# Altium schematic sheet 2414_ScreenHolder.SchDoc
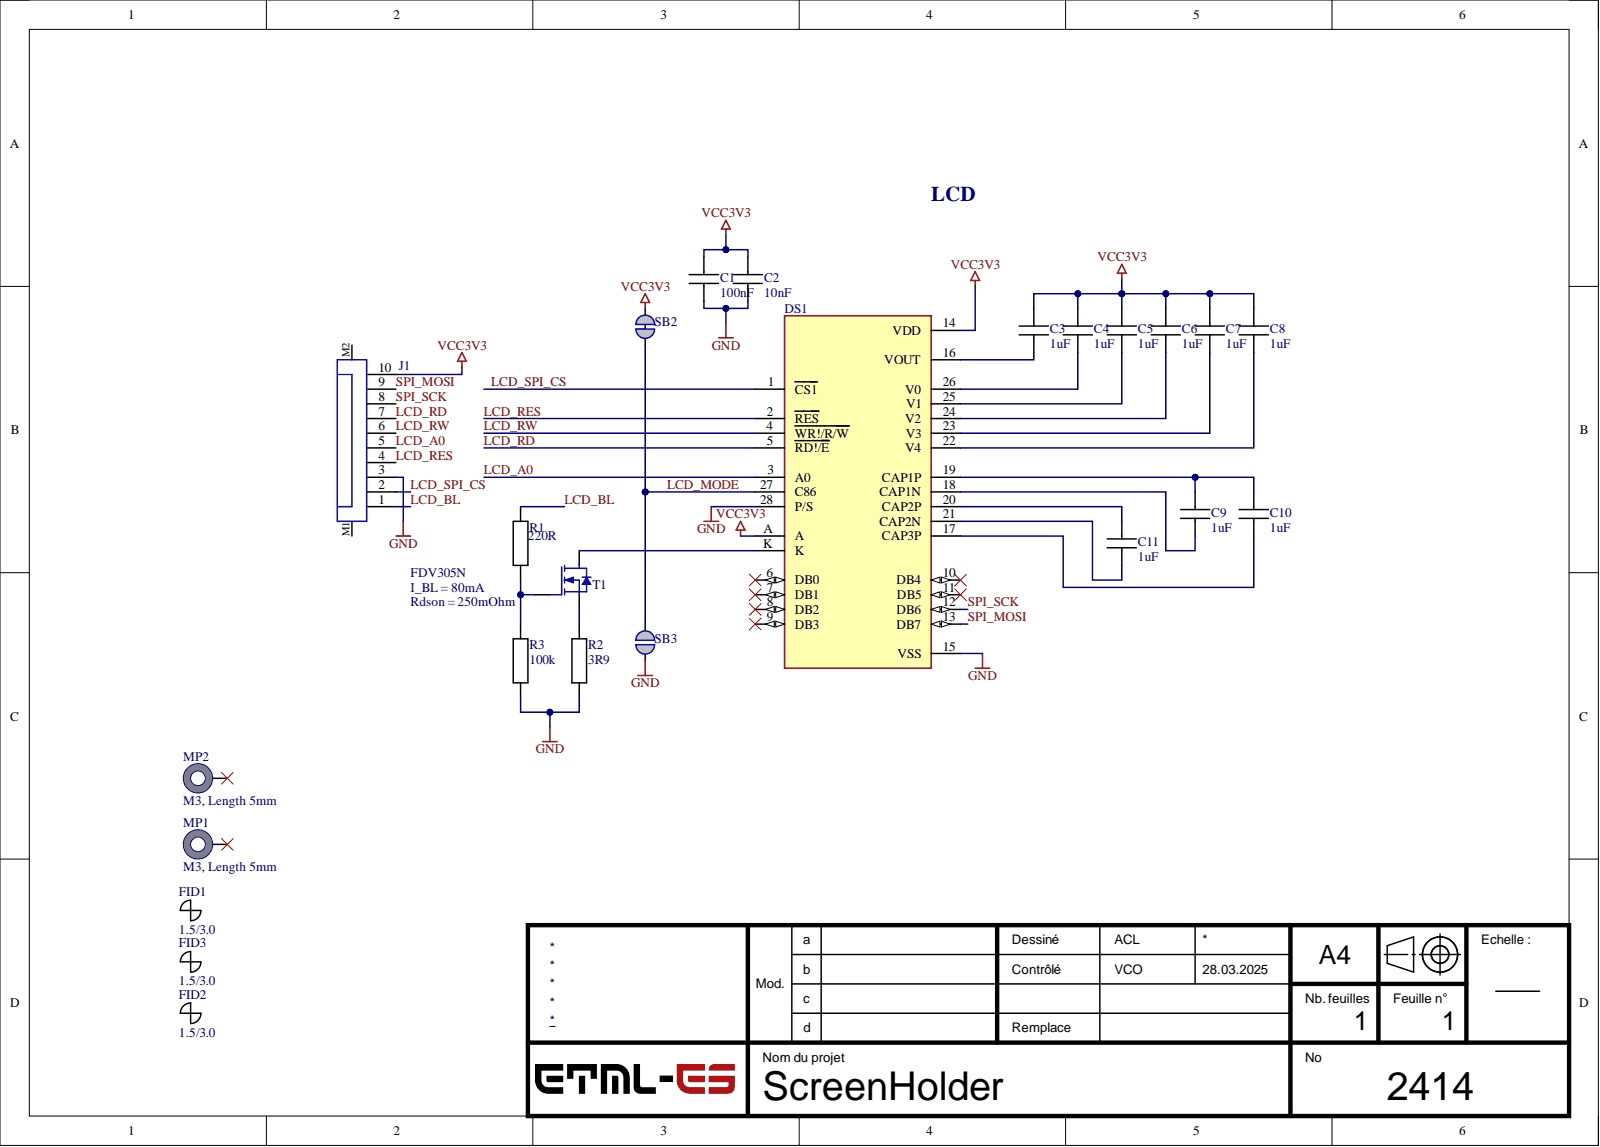
[diagram p0: 2414_ScreenHolder.SchDoc]

## Parts
C1  100nF  [CAPC1608X08M]
C2  10nF  [CAPC1608X08M]
C3  1uF  [CAPC1608X08M]
C4  1uF  [CAPC1608X08M]
C5  1uF  [CAPC1608X08M]
C6  1uF  [CAPC1608X08M]
C7  1uF  [CAPC1608X08M]
C8  1uF  [CAPC1608X08M]
C9  1uF  [CAPC1608X08M]
C10  1uF  [CAPC1608X08M]
C11  1uF  [CAPC1608X08M]
DS1  NHD-C12864LZ-FSW-FBW-3V3  [LCD_NHD-C12864LZ-FSW-FBW-3V3]  (value not drawn)
FID1  1.5/3.0  [FID-150-300]  (no BOM)
FID2  1.5/3.0  [FID-150-300]  (no BOM)
FID3  1.5/3.0  [FID-150-300]  (no BOM)
J1  68611014422  [68611014422]  (value not drawn)
MP1  M3, Length 5mm  Length: 5mm  [9774050360]
MP2  M3, Length 5mm  Length: 5mm  [9774050360]
R1  220R  [RESC1608X06M]
R2  3R9  [RESC1608X06M]
R3  100k  [RESC1608X06M]
SB2  Solderbridge  [Solderbridge_Shorted]  (value not drawn)
SB3  Solderbridge  [Solderbridge_4]  (value not drawn)  (no BOM)
T1  FDV305N  [SOT95P240X111-3N]

## Nets
GND: C1.1 C2.1 DS1.15(VSS) DS1.28(P/S) J1.3 R2.1 R3.1 SB3.2
VCC3V3: C1.2 C2.2 C3.2 C4.2 C5.2 C6.2 C7.2 C8.2 DS1.14(VDD) DS1.A J1.10 SB2.1
LCD_A0: DS1.3(A0) J1.5
LCD_BL: J1.1 R1.2
LCD_MODE: DS1.27(C86) SB2.2 SB3.1
LCD_RD: DS1.5(RD!/E) J1.7
LCD_RES: DS1.2(RES) J1.4
LCD_RW: DS1.4(WR!/R/W) J1.6
LCD_SPI_CS: DS1.1(CS1) J1.2
SPI_MOSI: DS1.13(DB7) J1.9
SPI_SCK: DS1.12(DB6) J1.8
NetC3_1: C3.1 DS1.16(VOUT)
NetC4_1: C4.1 DS1.26(V0)
NetC5_1: C5.1 DS1.25(V1)
NetC6_1: C6.1 DS1.24(V2)
NetC7_1: C7.1 DS1.23(V3)
NetC8_1: C8.1 DS1.22(V4)
NetC9_1: C9.1 DS1.18(CAP1N)
NetC9_2: C9.2 C10.2 DS1.19(CAP1P)
NetC10_1: C10.1 DS1.17(CAP3P)
NetC11_1: C11.1 DS1.21(CAP2N)
NetC11_2: C11.2 DS1.20(CAP2P)
NetDS1_K: DS1.K T1.3
NetR1_1: R1.1 R3.2 T1.1
NetR2_2: R2.2 T1.2

Not connected: DS1 6(DB0) 7(DB1) 8(DB2) 9(DB3) 10(DB4) 11(DB5); J1 M1 M2; MP1 1; MP2 1

## Library data of the parts
C1 = CMP-065-000000-2: CAPC 100nF X7R 50V 10% 1608 (0603 INCH); Manufacturer: TDK; Manufacturer Number: CGA3E2X7R1H104K080AA; Current: NULL; IsStocked: 1; PN: PN-338083; TemperatureRange: -55°C to +125°C; Category: Passives; Component Kind: Standard; Dielectric: X7R; Library Name: ETML-ES; Power: NULL; SubCategory: Condensateurs ceramique; Supplier 1: ETML; Supplier Part Number 1: FAB.0603_C 100n; Supplier Price 1: 0.0100; TemperatureCoeff: NULL; Tolerance: ±10%; Value: 100nF; Voltage: 50V
C2 = CMP-065-000010-2: CAPC 10nF X7R 50V 10% 1608 (0603 INCH); Manufacturer: TDK; Manufacturer Number: C1608X7R1H103K080AA; IsStocked: 1; PN: PN-514948; TemperatureRange: -55°C to +125°C; Category: Passives; Component Kind: Standard; Dielectric: X7R; Library Name: ETML-ES; SubCategory: Condensateurs ceramique; Supplier 1: ETML; Supplier Part Number 1: FAB.0603_C 10nF; Supplier Price 1: 0.0123; Tolerance: ±10%; Value: 10nF; Voltage: 50V
C3-C11 = CMP-065-000004-2: CAPC 1uF X5R 50V 10% 1608 (0603 INCH); Manufacturer: TDK; Manufacturer Number: C1608X5R1H105K080AB; IsStocked: 1; PN: PN-700698; TemperatureRange: -55°C to +85°C; Value: 1µF; Category: Passives; Component Kind: Standard; Dielectric: X5R; Library Name: ETML-ES; SubCategory: Condensateurs ceramique; Supplier 1: ETML; Supplier Part Number 1: FAB.0603_C 1uF; Supplier Price 1: 0.0288; Tolerance: ±10%; Voltage: 50V
DS1 = NHD-C12864LZ-FSW-FBW-3V3: Graphic LCD Display Module Transflective Black (White - Inverted) FSTN - Film Super-Twisted Nematic Parallel/Serial 128 x 64; MF: Newhaven Display; Availability: Warning; Check_prices: https://www.snapeda.com/parts/NHD-C12864LZ-FSW-FBW-3V3/Newhaven%20Display%20Intl/view-part/299301/?ref=eda; Component Kind: Standard; MP: NHD-C12864LZ-FSW-FBW-3V3; Package: None; Price: None; Purchase-URL: https://pricing.snapeda.com/search/part/NHD-C12864LZ-FSW-FBW-3V3/?ref=eda; SnapEDA_Link: https://www.snapeda.com/parts/NHD-C12864LZ-FSW-FBW-3V3/Newhaven%20Display%20Intl/view-part/299301/?ref=snap; Library Name: 2414_TesteurDeSprinkler_SPB7.SchLib
FID1-FID3 = CMP-063-000021-1: FID 1.5/3.0; IsStocked: False; PN: PN-509779; Category: Others; Library Name: ETML-ES; SubCategory: Fiducials; Value: 1.5/3.0
J1 = CMP-1502-01457-2: NexarPartId: 8917674; Actuator Material: Polyphenylene; Contact Resistance: 20mΩ; Current Rating: 1A; Gender: Male; Insulation Resistance: 100MΩ; Number of Contacts: 10; Operating Supply Voltage: 125V; Pitch: 1mm; Component Kind: Standard; Contact Material: Bronze, Tin; Contact Plating: Tin; Flammability Rating: UL94 V-0; Housing Material: Polyphenylene; Lead Pitch: 1mm; Length: 17mm; Library Name: Altium Content Vault; Max Operating Temperature: 85°C; Min Operating Temperature: -25°C; Mount: Surface Mount; Number of Pins: 10; Number of Positions: 10; Number of Rows: 1; Orientation: Horizontal; Packaging: Tape and Reel; REACH SVHC: Unknown; RoHS: Compliant; Termination: Solder; Voltage Rating: 125V; Width: 3mm
MP1 MP2 = 9774050360: WA-SMSI SMT Steel Spacer, M3 Thread Internal, Length 5mm; InnerDiameter: 4.2mm; OuterDiameter: 6mm; Component Kind: Standard; ComponentLink1Description: Manufacturer; ComponentLink1URL: http://www.we-online.de; ComponentLink2Description: Datasheet; ComponentLink2URL: http://katalog.we-online.de/em/datasheet/9774050360.pdf; Packaging: Bulk; PartNumber: 9774050360; PublishedDate: Dec. 2016; Supplier: Stock ES; Thread: M3; Value: M3, Length 5mm; Publisher: Wurth Elektronik
R1 = CMP-066-000104-2: RES 220ohm  ±1% 0.1W  1608 (0603 INCH); Manufacturer: Yageo; Manufacturer Number: RC0603FR-07220RL; IsStocked: 1; PN: PN-315954; TemperatureCoeff: ±100ppm/°C; TemperatureRange: -55°C to 155°C; Value: 220; Voltage: 75V; Category: Passives; Component Kind: Standard; Library Name: ETML-ES; Power: 0.1W; SubCategory: Resistances couche epaisse; Supplier 1: ETML; Supplier Part Number 1: FAB.0603_R 220R; Supplier Price 1: 0.0200; Tolerance: ±1%
R2 = CMP-066-000083-2: RES 3.9ohm  ±1% 0.1W  1608 (0603 INCH); Manufacturer: Yageo; Manufacturer Number: RC0603FR-073R9L; IsStocked: 1; PN: PN-966079; TemperatureCoeff: ±100ppm/°C; TemperatureRange: -55°C to 155°C; Value: 3.9; Voltage: 75V; Category: Passives; Component Kind: Standard; Library Name: ETML-ES; Power: 0.1W; SubCategory: Resistances couche epaisse; Supplier 1: ETML; Supplier Part Number 1: FAB.0603_R 3R9; Supplier Price 1: 0.0200; Tolerance: ±1%
R3 = CMP-066-000136-2: RES 100kohm  ±1% 0.1W  1608 (0603 INCH); Manufacturer: Yageo; Manufacturer Number: RC0603FR-07100KL; IsStocked: 1; PN: PN-525286; TemperatureCoeff: ±100ppm/°C; TemperatureRange: -55°C to 155°C; Voltage: 75V; Category: Passives; Component Kind: Standard; Library Name: ETML-ES; Power: 0.1W; SubCategory: Resistances couche epaisse; Supplier 1: ETML; Supplier Part Number 1: FAB.0603_R 100k; Supplier Price 1: 0.0100; Tolerance: ±1%; Value: 100k
SB2 = Solderbridge Shorted: Shorted Solderbridge; Artikkelnr Noca: N.A.; Pad Count: 2; Component Class: Connectors; Component Kind: Net Tie; ComponentLink1Description: Datasheet; ComponentLink1URL: N.A.; ComponentLink2Description: Supplier link; ComponentLink2URL: N.A.; Footprint Doc: Solderbridge_Shorted; HelpURL: N.A.; LatestRevisionDate: 2012-08-07; Manufacturer: N.A.; Manufacturer Part Number: N.A.; Note: N.A.; Part Number: Solderbridge Shorted; Part number IPS: N.A.; Part number Norautron: N.A.; PartId: 100500459; Pin Count: 2; Published: 2012-08-07; Supplier 1: N.A.; Supplier 2: N.A.; Supplier Part Number 1: N.A.; Supplier Part Number 2: N.A.; Value: Solderbridge; Author: rsk; Library Name: 2414_ScreenHolder.SchLib; Publisher: Nordic Semiconductor
SB3 = Solderbridge: Artikkelnr Noca: N.A.; Pad Count: 2; Component Class: Connectors; ComponentLink1Description: Datasheet; ComponentLink1URL: N.A.; ComponentLink2Description: Supplier link; ComponentLink2URL: N.A.; Description: Solderbridge; Footprint Doc: Solderbridge; HelpURL: N.A.; LatestRevisionDate: 2009-12-14; Manufacturer: N.A.; Manufacturer Part Number: N.A.; Note: N.A.; Part Number: Solderbridge; Part number IPS: N.A.; Part number Norautron: N.A.; PartId: 100500458; Pin Count: 2; Published: 2009-12-14; Supplier 1: N.A.; Supplier 2: N.A.; Supplier Part Number 1: N.A.; Supplier Part Number 2: N.A.; Value: Solderbridge; Author: rsk; Library Name: 2414_ScreenHolder.SchLib; Publisher: Nordic Semiconductor
T1 = NEMOS-GSD-3_A: Small Signal Field-Effect Transistor, 0.9A I(D), 20V, 1-Element, N-Channel, Silicon, Metal-oxide Semiconductor FET; Manufacturer: ON Semiconductor / Fairchild; Drain Current-Max (Abs) (ID): 900mA; Drain-source On Resistance-Max: 220mR; Number of Elements: 1; Operating Mode: ENHANCEMENT MODE; Package Body Material: Plastic; Power Dissipation-Max (Abs): 350mW; Qualification Status: Not Qualified; Transistor Application: SWITCHING; Category: MOSFETs; Component Kind: Standard; ComponentLink1Description: https://datasheet.ciiva.com:443/pdfs/VipMasterIC/IC/ONSM/ONSM-S-A0003586886/ONSM-S-A0003587639-1.pdf?src-supplier=IHS+Markit; ComponentLink1URL: https://datasheet.ciiva.com:443/pdfs/VipMasterIC/IC/ONSM/ONSM-S-A0003586886/ONSM-S-A0003587639-1.pdf?src-supplier=IHS+Markit; ComponentLink2Description: https://datasheet.ciiva.com/931/fdv305n-931845.pdf?src-supplier=Digikey; ComponentLink2URL: https://datasheet.ciiva.com/931/fdv305n-931845.pdf?src-supplier=Digikey; ComponentLink3Description: https://datasheet.ciiva.com/6037/184807-6037429.pdf?src-supplier=Farnell; ComponentLink3URL: https://datasheet.ciiva.com/6037/184807-6037429.pdf?src-supplier=Farnell; ComponentLink4Description: https://datasheet.ciiva.com/4440/fdv305n-79611-4440195.pdf?src-supplier=Mouser; ComponentLink4URL: https://datasheet.ciiva.com/4440/fdv305n-79611-4440195.pdf?src-supplier=Mouser; ComponentLink5Description: https://datasheet.ciiva.com/34301/899087-34301698.pdf?src-supplier=Jameco; ComponentLink5URL: https://datasheet.ciiva.com/34301/899087-34301698.pdf?src-supplier=Jameco; ComponentLink6Description: https://datasheet.ciiva.com/931/fdv305n-931846.pdf?src-supplier=Digikey; ComponentLink6URL: https://datasheet.ciiva.com/931/fdv305n-931846.pdf?src-supplier=Digikey; ComponentLink7Description: https://datasheet.ciiva.com/931/fdv305n-931847.pdf?src-supplier=Digikey; ComponentLink7URL: https://datasheet.ciiva.com/931/fdv305n-931847.pdf?src-supplier=Digikey; ComponentLink8Description: https://datasheet.ciiva.com/8114/fdv305n-8114718.pdf?src-supplier=Avnet; ComponentLink8URL: https://datasheet.ciiva.com/8114/fdv305n-8114718.pdf?src-supplier=Avnet; ComponentLink9Description: https://datasheet.ciiva.com/17483/fdv305n-17483853.pdf?src-supplier=Future+Electronics; ComponentLink9URL: https://datasheet.ciiva.com/17483/fdv305n-17483853.pdf?src-supplier=Future+Electronics; Drain Current-Max (ID): 900mA; DS Breakdown Voltage-Min: 20V; FET Technology: METAL-OXIDE SEMICONDUCTOR; JESD-30 Code: R-PDSO-G3; Library Name: 11020E.SCHLIB; Manufacturer Part Number: FDV305N; Max Operating Temperature: 150°C; Moisture Sensitivity Level: 1; Package Shape: Rectangular; Package Style: SMALL OUTLINEMeter; Pins: 3; Polarity/Channel Type: N-CHANNEL; Pricing: 10=0,072, 100=0,159, 200=0,146, 250=0,143, 500=0,14 (CHF); Reach SVHC Compliant: Yes; RoHS: Yes; RoHS Compliant: Yes; Stock: 2800; Supplier: Rs; Supplier Part Number: 6710797; Surface Mount: Yes; Terminal Form: Gull Wing; Terminal Position: DUAL; Transistor Element Material: SILICON
